# Altium schematic sheet 外围电路.SchDoc
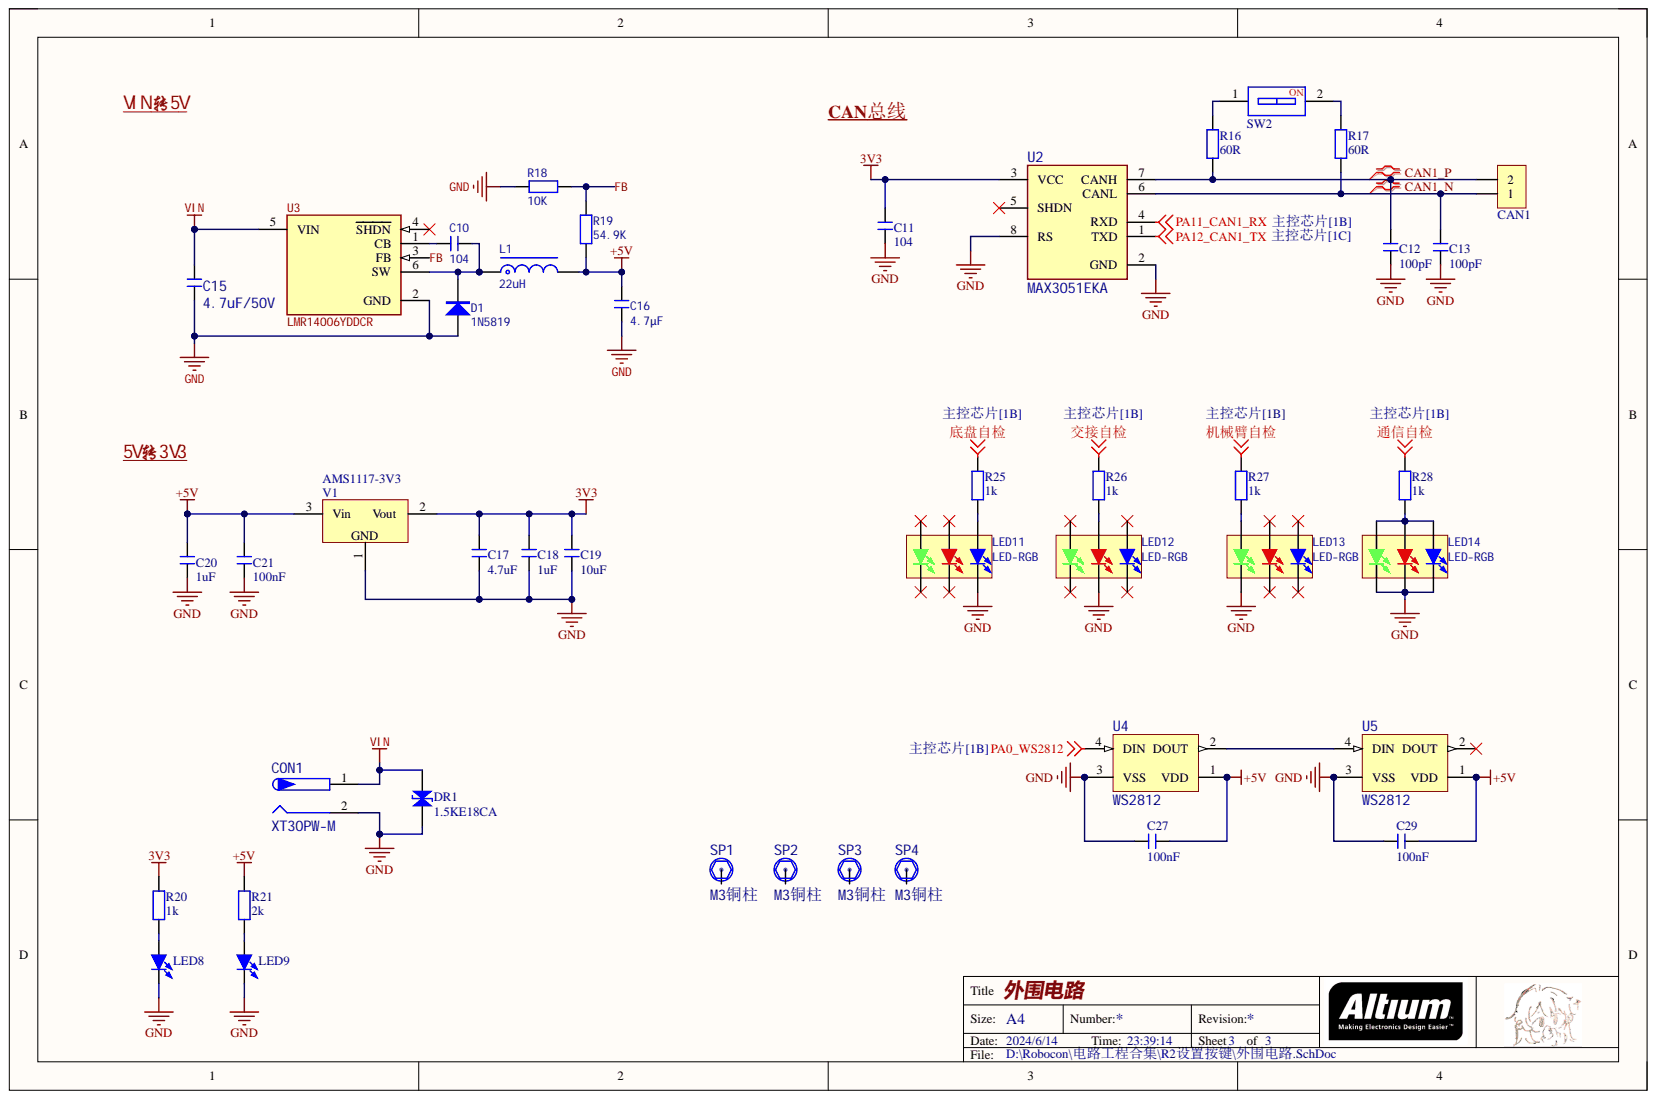
[diagram p0: 外围电路.SchDoc]

## Parts
C10  104  [C 0603_L]
C11  104  [C 0603_L]
C12  100pF  [C 0603_L]
C13  100pF  [C 0603_L]
C15  4.7uF/50V  [C 1210_L]
C16  4.7μF  [C 0603_L]
C17  4.7uF  [C 0603_L]
C18  1uF  [C 0603_L]
C19  10uF  [C 0603_L]
C20  1uF  [C 0603_L]
C21  100nF  [C 0603_L]
C27  100nF  [C 0603_L]
C29  100nF  [C 0603_L]
CAN1  HDR-1X2  [XH2.54-WS-2P]  (value not drawn)
CON1  XT30PW-M  [XT30PW-M]
D1  1N5819  [SOD-123]
DR1  1.5KE18CA  [SMB]
L1  22uH  [NR4030]
LED8  红色  [LED 0603W]  (value not drawn)
LED9  红色  [LED 0603W]  (value not drawn)
LED11  LED-RGB  [LED 普亮5050]
LED12  LED-RGB  [LED 普亮5050]
LED13  LED-RGB  [LED 普亮5050]
LED14  LED-RGB  [LED 普亮5050]
R16  60R  [R 0603_L]
R17  60R  [R 0603_L]
R18  10K  [R 0603_L]
R19  54.9K  [R 0603_L]
R20  1k  [R 0603_L]
R21  2k  [R 0603_L]
R25  1k  [R 0603_L]
R26  1k  [R 0603_L]
R27  1k  [R 0603_L]
R28  1k  [R 0603_L]
SP1  M3铜柱  [M3x4_L]
SP2  M3铜柱  [M3x4_L]
SP3  M3铜柱  [M3x4_L]
SP4  M3铜柱  [M3x4_L]
SW2  SW-1P  [SW-SMD_DSHP01TSGER]  (value not drawn)
U2  MAX3051EKA  [Texas_Instruments_DCN0008A_IPC_C]
U3  LMR14006YDDCR  [Texas_Instruments_DDC0006A_0]
U4  WS2812  [WS2812B_B]
U5  WS2812  [WS2812B_B]
V1  AMS1117-3V3  [SOT223_L]

## Nets
3V3: C11.2 C17.2 C18.2 C19.2 R20.2 U2.3(VCC) V1.2
+5V: C16.1 C20.2 C21.2 C27.1 C29.1 L1.2 R19.1 R21.2 U4.1(VDD) U5.1(VDD) V1.3
GND: C11.1 C12.1 C13.1 C15.1 C16.2 C17.1 C18.1 C19.1 C20.1 C21.1 C27.2 C29.2 CON1.2 D1.1 DR1.1 LED8.2 LED9.2 LED11.4 LED12.5 LED13.6 LED14.4 LED14.5 LED14.6 R18.2 U2.2(GND) U2.8(RS) U3.2(GND) U4.3(VSS) U5.3(VSS) V1.1
PA0_WS2812: U4.4(DIN)
PA11_CAN1_RX: U2.4(RXD)
PA12_CAN1_TX: U2.1(TXD)
VIN: C15.2 CON1.1 DR1.2 U3.5(VIN)
交接自检: R26.2
底盘自检: R25.2
机械臂自检: R27.2
通信自检: R28.2
CAN1_N: C13.2 CAN1.1 R17.1 U2.6(CANL)
CAN1_P: C12.2 CAN1.2 R16.1 U2.7(CANH)
FB: R18.1 R19.2 U3.3(FB)
NetC10_1: C10.1 D1.2 L1.1 U3.6(SW)
NetC10_2: C10.2 U3.1(CB)
NetLED8_1: LED8.1 R20.1
NetLED9_1: LED9.1 R21.1
NetLED11_3: LED11.3 R25.1
NetLED12_2: LED12.2 R26.1
NetLED13_1: LED13.1 R27.1
NetLED14_1: LED14.1 LED14.2 LED14.3 R28.1
NetR16_2: R16.2 SW2.1
NetR17_2: R17.2 SW2.2
NetU4_2: U4.2(DOUT) U5.4(DIN)

Not connected: LED11 1 2 5 6; LED12 1 3 4 6; LED13 2 3 4 5; SP1 1; SP2 1; SP3 1; SP4 1; U2 5(SHDN); U3 4(SHDN); U5 2(DOUT)

## Library data of the parts
C10 C16 = C: 无极性贴片电容; 材质: X7R; BOM名称: 无极性贴片电容; Component Kind: Standard; Library Name: 3.1   - 电阻-电容-电感.SchLib
C11-C13 C17-C21 C27 C29 = C: 贴片电容; BOM名称: 无极性贴片电容; 淘宝ID: 封装库来自淘宝 ID：黄晶宝石; Component Kind: Standard; Library Name: 0.1 - 电阻-电容-电感.SchLib; 唯一出售店铺网址: https://shop463601444.taobao.com; 联系售后，技术支持: https://shop463601444.taobao.com
C15 = C_1: 无极性贴片电容; 材质: X7R; BOM名称: 无极性贴片电容; Component Kind: Standard; Library Name: 锐角.SCHLIB
CAN1 = Header 2: 2P接插件; 版权归属: 封装库来自淘宝 ID：黄晶宝石; BOM名称: 2P接插件; Component Kind: Standard; Library Name: 5.4 - 接插件-脚距2.54 (100mil) .SchLib; 联系售后: https://shop463601444.taobao.com
CON1 = XT30PW-M: XT30PW-M PCB板专用卧式连接器，低温升阻燃插头; Component Kind: Standard; Library Name: CMD_G474.SCHLIB
D1 = 1N5819: 肖特基二极管; 属性: 40V/2A; BOM名称: 肖特基二极管; Component Kind: Standard; Library Name: 8.1   - 二极管-整流桥.SchLib
DR1 = DR: 瞬态电压抑制二极管; 版权归属: 封装库来自淘宝 ID：黄晶宝石; BOM名称: 瞬态电压抑制二极管; Component Kind: Standard; Library Name: 0.2 - 二极管-整流桥.SchLib; 学习资源: https://shop463601444.taobao.com
L1 = L-NR: NR磁胶电感; BOM名称: NR磁胶电感; Component Kind: Standard; Library Name: 3.1   - 电阻-电容-电感.SchLib
LED8 LED9 = LED-SMD: 贴片LED; 版权归属: 封装库来自淘宝 ID：黄晶宝石; BOM名称: 贴片LED; Component Kind: Standard; Library Name: 0.3 - LED发光二极管.SchLib; 学习资源: https://shop463601444.taobao.com
LED11-LED14 = LED-RGB: 三基色LED; BOM名称: 三基色LED; Component Kind: Standard; Library Name: 功率计.SCHLIB
R16 R17 R20 R21 R25-R28 = R: 贴片电阻; 封装库来自淘宝卖家 ID：黄晶宝石: 其他店铺购入均为盗版，不享受售后技术支持服务，请立即申请退款; 版权归属: 来自淘宝 ID：黄晶宝石; BOM名称: 贴片电阻; Component Kind: Standard; Library Name: 0.1 - 电阻-电容-电感.SchLib; 联系售后: https://shop463601444.taobao.com
R18 R19 = R: 贴片电阻; BOM名称: 贴片电阻; Component Kind: Standard; Library Name: 3.1   - 电阻-电容-电感.SchLib
SP1-SP4 = 铜柱小焊盘: 铜柱; BOM名称: 铜柱; Component Kind: Standard; Library Name: IMU_ENC_F7.SCHLIB
SW2 = SW-1P: 1路编码开关; 学习资源: 封装库来自淘宝 ID：黄晶宝石; BOM名称: 1路编码开关; Component Kind: Standard; Library Name: 0.7 - 常用按键-开关.SchLib; 技术支持，联系售后: https://shop463601444.taobao.com
U2 = MAX3051EKA: CAN 1Mbps Shutdown/Standby 3.3V 8-Pin SOT-23 T/R; Component Kind: Standard; Library Name: CMD_G474.SCHLIB
U3 = LMR14006YDDCR: SIMPLE SWITCHER® 4-V to 40-V, 600-mA step-down converter with Low Iq 6-SOT-23-THIN -40 to 125; Component Kind: Standard; Library Name: 钝角.SCHLIB
U4 U5 = WS2812: Intelligent Control LED Integrated Light Source, 3.5 to 5.3 V, -25 to 80 degC, 4-Pin SMD, RoHS, Bulk; PartNumber: WS2812B; Case\Package: Radial; Current Rating: 240 mA; Shielding: Unshielded; Tolerance: 10%; Component Kind: Standard; ComponentLink1Description: Manufacturer URL; ComponentLink1URL: https://www.adafruit.com/; ComponentLink2Description: Datasheet; ComponentLink2URL: https://cdn-shop.adafruit.com/datasheets/WS2812B.pdf; DatasheetVersion: May-13; Height: 12.2 mm; Lead Pitch: 5 mm; Length: 12.2 mm; Max Operating Temperature: 125 degC; Min Operating Temperature: -55 degC; Mount: Through Hole; Package Quantity: 200; PackageDescription: 4-Pin Surface Mount Device, Body 5 x 5 mm, Pitch 3.3 mm; PackageReference: WS2812B; Packaging: Bulk; Pins: 2; Radiation Hardening: No; REACH SVHC: No SVHC; RoHSCompliant: true; Library Name: IMU_ENC_F7.SCHLIB
V1 = AMS1117: 三端稳压芯片; BOM名称: 稳压芯片; 淘宝ID: 封装库来自淘宝 ID：黄晶宝石; Component Kind: Standard; Library Name: 1.1 - 电源类芯片.SchLib; 欢迎光临淘宝店铺: https://shop463601444.taobao.com
